# Altium schematic sheet programmer-shield.SchDoc(programmer-shield)
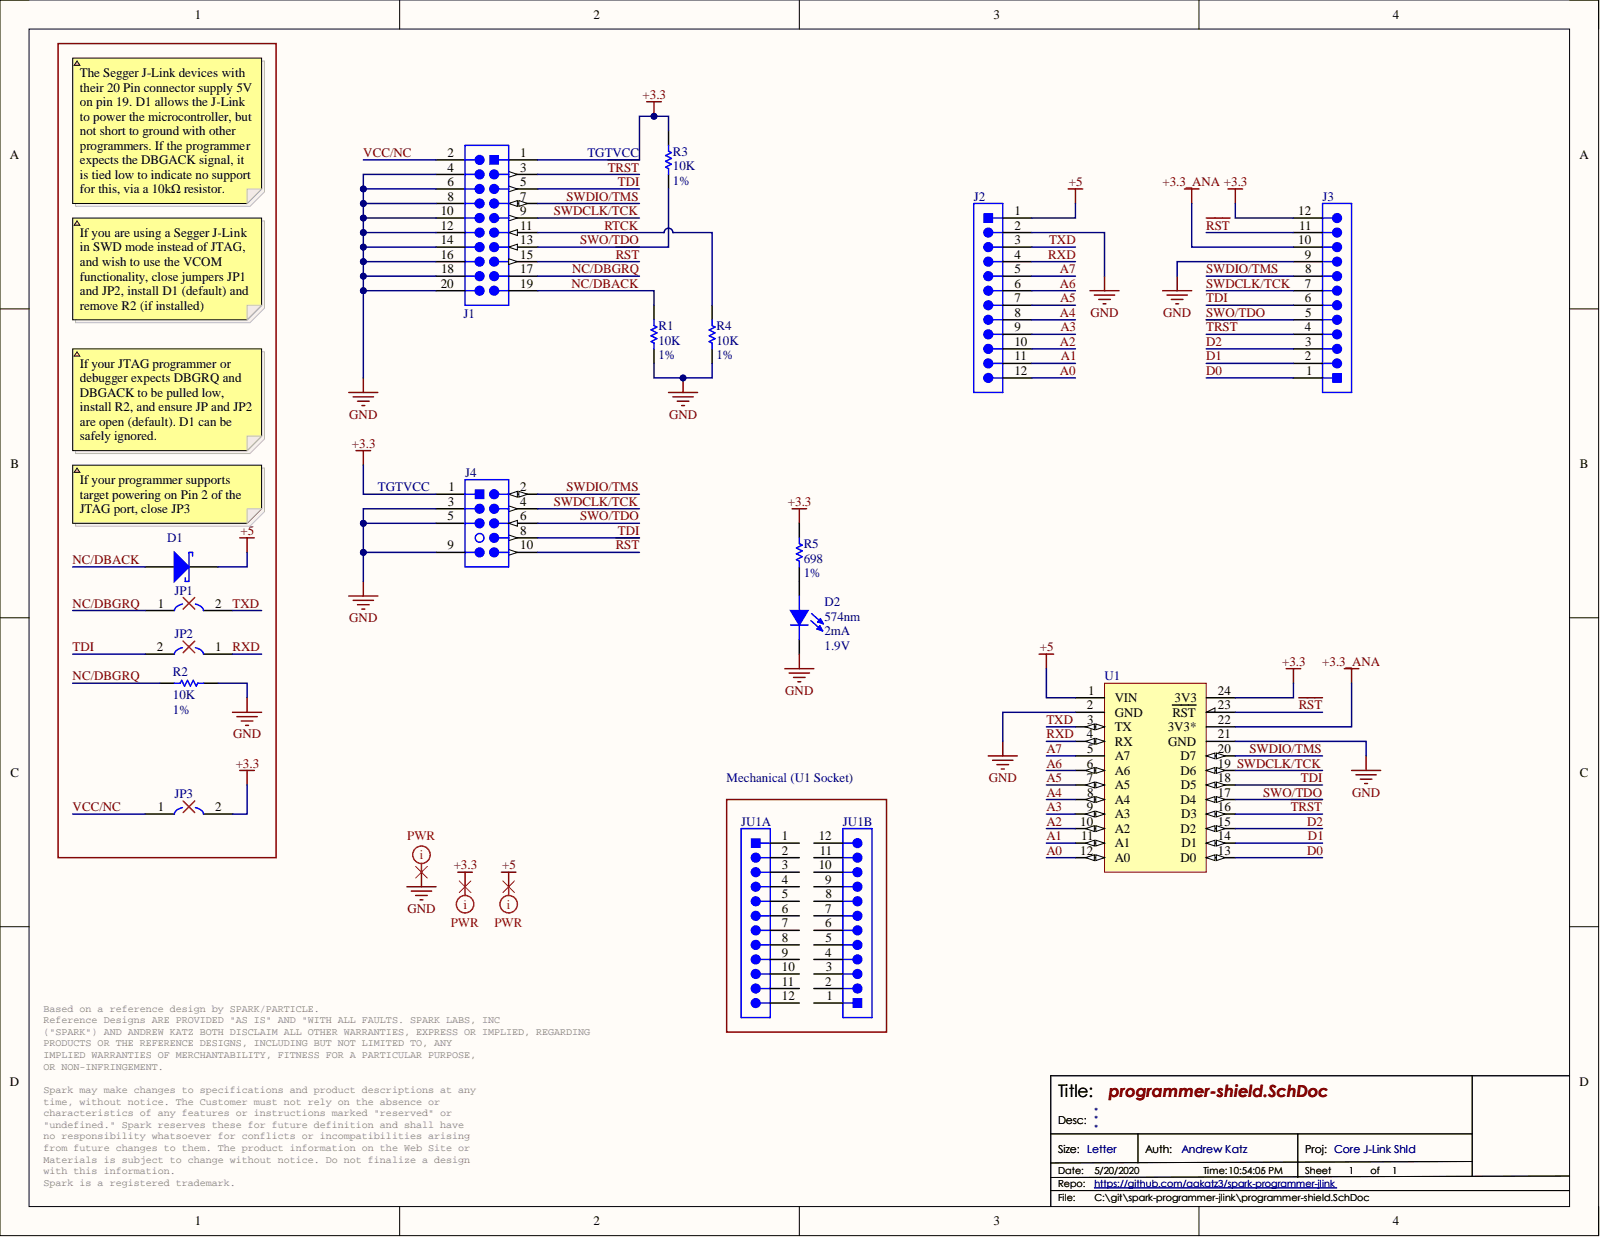
[diagram p0: programmer-shield.SchDoc]

## Parts
D1  1A 20V Schottky Diode  [SOD-123FL]  (value not drawn)
D2  Dominant Wavelength: 574nm  Forward Current: 2mA  Forward Voltage: 1.9V  [LEDC2012X135N_SM0805GCL(Primary)]  (hidden comment: SM0805GCL)
J1  Arm® JTAG 20 connector  [TST-110-01-G-D]  (value not drawn)
J2  12 contacts Female header  [SSW-112-01-G-S]  (value not drawn)
J3  12 contacts Female header  [SSW-112-01-G-S]  (value not drawn)
J4  Cortex Debug, 0.050" 10 Pin  [FTSH-105-01-F-DV-007-K]  (value not drawn)
JP1  0603 DNP  [0603_JP_Open]  (value not drawn)  (no BOM)
JP2  0603 DNP  [0603_JP_Open]  (value not drawn)  (no BOM)
JP3  0603 DNP  [0603_JP_Open]  (value not drawn)  (no BOM)
JU1A  12 contacts Female header  [SSW-112-01-G-S]  (value not drawn)  (mechanical)
JU1B  12 contacts Female header  [SSW-112-01-G-S]  (value not drawn)  (mechanical)
R1  value 10K  Tol: 1%  Val: 10K  [0805_RES]  (hidden comment: RES 0805 10K 1%)
R2  value 10K  Tol: 1%  Val: 10K  [0805_RES]  (hidden comment: RES 0805 10K 1%)
R3  value 10K  Tol: 1%  Val: 10K  [0805_RES]  (hidden comment: RES 0805 10K 1%)
R4  value 10K  Tol: 1%  Val: 10K  [0805_RES]  (hidden comment: RES 0805 10K 1%)
R5  value 698  Tol: 1%  [0805_RES]  (hidden comment: RES 0805 698 1%)
U1  Particle/Spark Core WiFi development board footprint  [SPARK_CORE _SOCKET]  (value not drawn)

## Nets
+3.3: J1.1 J3.12 J4.1 JP3.2 R3.2 R5.2 U1.24(3V3)
+3.3_ANA: J3.10 U1.22(3V3*)
+5: D1.K J2.1 U1.1(VIN)
GND: D2.C J1.4 J1.6 J1.8 J1.10 J1.12 J1.14 J1.16 J1.18 J1.20 J2.2 J3.9 J4.3 J4.5 J4.9 R1.1 R2.1 R4.1 U1.2(GND) U1.21(GND)
~{RST}: J1.15 J3.11 J4.10 U1.23(RST)
~{TRST}: J1.3 J3.4 U1.16(D3)
A0: J2.12 U1.12(A0)
A1: J2.11 U1.11(A1)
A2: J2.10 U1.10(A2)
A3: J2.9 U1.9(A3)
A4: J2.8 U1.8(A4)
A5: J2.7 U1.7(A5)
A6: J2.6 U1.6(A6)
A7: J2.5 U1.5(A7)
D0: J3.1 U1.13(D0)
D1: J3.2 U1.14(D1)
D2: J3.3 U1.15(D2)
NC/DBACK: D1.A J1.19 R1.2
NC/DBGRQ: J1.17 JP1.1 R2.2
RTCK: J1.11 R4.2
RXD: J2.4 JP2.1 U1.4(RX)
SWDCLK/TCK: J1.9 J3.7 J4.4 U1.19(D6)
SWDIO/TMS: J1.7 J3.8 J4.2 U1.20(D7)
SWO/TDO: J1.13 J3.5 J4.6 R3.1 U1.17(D4)
TDI: J1.5 J3.6 J4.8 JP2.2 U1.18(D5)
TXD: J2.3 JP1.2 U1.3(TX)
VCC/NC: J1.2 JP3.1
NetD2_A: D2.A R5.1

Not connected: JU1A 1 2 3 4 5 6 7 8 9 10 11 12; JU1B 1 2 3 4 5 6 7 8 9 10 11 12

## Library data of the parts
D1 = NRVB120VLSFT1G: 1A 20V Schottky Diode SOD-123FL; Manufacturer: On Semiconductor; Database Table Name: Rectifier Diodes; Diode Configuration: Single; Grade: AEC-Q101 (Automotive - Discretes); If (Amps): 1; Ifsm (Amps): 45; Package Class: Surface Mount; Vf (V): 0.3; VRRM (V): 20; Component Kind: Standard; ComponentLink1Description: Manufacturer Website; ComponentLink2Description: Component Datasheet; ComponentLink2URL: https://www.onsemi.com/pub/Collateral/MBR120VLSFT1-D.PDF; ComponentLink3Description: Octopart Link; Description in Visual: 1A 20V Schottky Diode SOD-123FL; Library Name: Discrete Semiconductors.DbLib; Manufacturer P/N: NRVB120VLSFT1G; P/N: NRVB120VLSFT1G; PCB Package: SOD-123FL; Supplier 1: Digi-Key; Supplier Part Number 1: NRVB120VLSFT1GOSCT-ND; Type: Schottky
D2 = SM0805GCL: Green 574nm LED Indication - Discrete 1.9V 0805 (2012 Metric); Manufacturer: Bivar; Lens Color: Colorless; Lens Transparency: Clear; Luminous Intensity: 3.6mcd; Viewing Angle: 130°; Case Code (Imperial): 0805; Case Code (Metric): 2012; Case/Package: 0805; Category: LEDs; Color: Green; Component Kind: Standard; Height: 0.8mm; Illumination Color: Green; Lead Free: Lead Free; Length: 2mm; Manufacturer Part Number: SM0805GCL; Max Operating Temperature: 80°C; Min Operating Temperature: -30°C; Mount: Surface Mount; Package Quantity: 4000; Packaging: Tape and Reel; Supplier 1: Digi-Key; Supplier Part Number 1: 492-2525-1-ND; Test Current: 2mA; Width: 1.2mm; Library Name: AERO Parts.IntLib
J1 = ARM Standard Debug: 20 Pin Shrouded Header, 0.100"; Manufacturer: Generic; Manufacturer P/N: Various; Database Table Name: Debug Connectors; Gender: Male; Number of Contacts: 20; Component Kind: Standard; ComponentLink1Description: Pinout Reference; ComponentLink1URL: http://infocenter.arm.com/help/index.jsp?topic=/com.arm.doc.100893_0100_02_en/ris1452189032721.html; Contact Finish: Gold; Description in Visual: 20 Pin Shrouded Header, 0.100"; Library Name: Connectors.DbLib; Number of Rows: 2; Orientation: Vertical; P/N: ARM Standard Debug; Pitch: 0.100"; Supplier 1: Digi-Key; Supplier 2: Digi-Key; Supplier 3: Digi-Key; Supplier 4: Digi-Key; Supplier 5: Digi-Key; Supplier 6: Digi-Key; Supplier 7: Digi-Key; Supplier 8: Digi-Key; Supplier Part Number 1: SAM8994-ND; Supplier Part Number 2: 3M11932-ND; Supplier Part Number 3: MHC20K-ND; Supplier Part Number 4: ED10524-ND; Supplier Part Number 5: S9172-ND; Supplier Part Number 6: MHC20E-ND; Supplier Part Number 7: A33165-ND; Supplier Part Number 8: MHC20E-ND
J2 J3 JU1A JU1B = SSW-112-01-G-S: Connector Female Header 1X12 vertical 12 contacts  pitch Gold finish; Manufacturer: SamTec; Database Table Name: Headers; Description in Visual: Con Hdr Female 12 contacts vertical 1X12; Number of Contacts: 12; ComponentLink1Description: Manufacturer Website; ComponentLink1URL: https://www.samtec.com; ComponentLink2Description: Component Datasheet; ComponentLink2URL: http://suddendocs.samtec.com/catalog_english/ssw_th.pdf; ComponentLink3Description: Octopart Link; ComponentLink3URL: https://octopart.com/ssw-112-01-g-s-003-samtec-15802181?r=sp; Contact Finish: Gold; Gender: Female; Library Name: Connectors.DbLib; Manufacturer P/N: SSW-112-01-G-S; Number of Rows: 1.00; Orientation: vertical; P/N: SSW-112-01-G-S; Supplier 1: Digi-Key; Supplier Part Number 1: SAM1209-12-ND
  J2 J3: Component Kind: Standard
J4 = ARM Cortex Debug: 10 Pin Shrouded Header, 0.050", Key Pin 7; Manufacturer: Samtech; Manufacturer P/N: Various; Database Table Name: Debug Connectors; Gender: Male; Number of Contacts: 10; Component Kind: Standard; ComponentLink1Description: Pinout Reference; ComponentLink1URL: http://infocenter.arm.com/help/topic/com.arm.doc.faqs/attached/13634/cortex_debug_connectors.pdf; Contact Finish: Gold; Description in Visual: 10 Pin Shrouded Header, 0.050", Key Pin 7; Library Name: Connectors.DbLib; Number of Rows: 2; Orientation: Vertical; P/N: ARM Cortex Debug; Pitch: 0.050"; Supplier 1: Digi-Key; Supplier 2: Digi-Key; Supplier 3: Digi-Key; Supplier Part Number 1: SAM15640-ND; Supplier Part Number 2: SAM13926CT-ND; Supplier Part Number 3: SAM11250-ND
JP1-JP3 = 0603 link (Open): 0Ω link, Solder Bridge/SMD, Open; Database Table Name: Shunts and Jumpers; Description in Visual: 0Ω link, Solder Bridge/SMD, Open; Library Name: Hardware.DbLib; P/N: 0603 link (Open); Simulation: Model Name
R1-R4 = RES 0805 10K 1%: Resistor Surface Mount, 10K Ohms, 0805 Footprint, 1% Tolerance 0.125W; Manufacturer: Various; Description in Visual: Res SMT 10K 0805  1%  0.125W; PCB Package: 0805; Component Kind: Standard; Database Table Name: Resistors; Library Name: Passive Components.DbLib; Manufacturer P/N: Various; P/N: RES 0805 10K 1%; Power_Rating: 0.125W; Simulation: Model Name; Tolerance: 1%
R5 = RES 0805 698 1%: Resistor Surface Mount, 698 Ohms, 0805 Footprint, 1% Tolerance 0.125W; Manufacturer: Various; Description in Visual: Res SMT 698 0805  1%  0.125W; PCB Package: 0805; Component Kind: Standard; Database Table Name: Resistors; Library Name: Passive Components.DbLib; Manufacturer P/N: Various; P/N: RES 0805 698 1%; Power_Rating: 0.125W; Simulation: Model Name; Tolerance: 1%; Val: 698
U1 = SPARK_CORE: Component Kind: Standard; Library Name: Particle-Devices.SCHLIB
